# Altium schematic sheet DSP.SchDoc
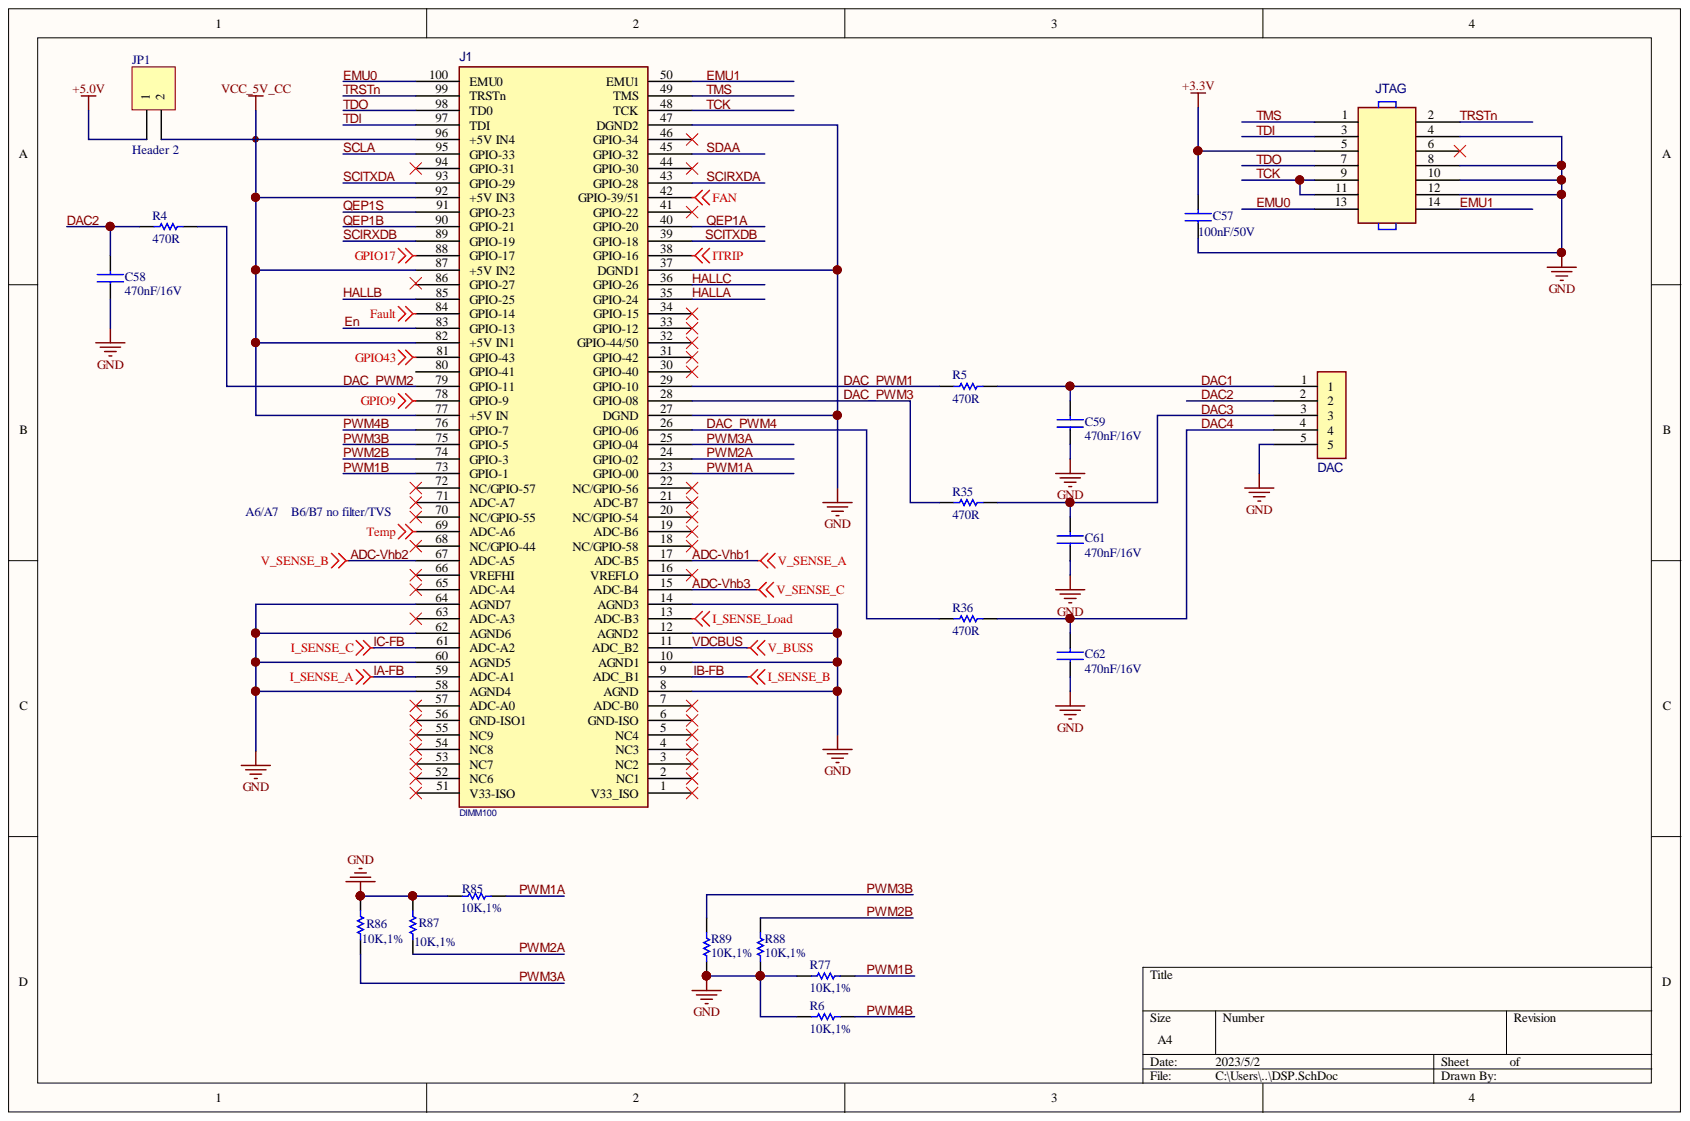
[diagram p0: DSP.SchDoc]

## Parts
C57  100nF/50V  [0603]
C58  470nF/16V  [0603]
C59  470nF/16V  [0603]
C61  470nF/16V  [0603]
C62  470nF/16V  [0603]
DAC  HEADER5x1  [HDR5X1_5.0mm]  (value not drawn)
J1  DIMM100  [DIM100_short]
JP1  Header 2  [HDR1X2]
JTAG  HEADER7x2  [HDR2X7-B]  (value not drawn)
R4  470R  [0603]
R5  470R  [0603]
R6  10K,1%  [0603]
R35  470R  [0603]
R36  470R  [0603]
R77  10K,1%  [0603]
R85  10K,1%  [0603]
R86  10K,1%  [0603]
R87  10K,1%  [0603]
R88  10K,1%  [0603]
R89  10K,1%  [0603]

## Nets
+3.3V: C57.2 JTAG.5
+5.0V: JP1.1
FAN: J1.42(GPIO-39/51)
Fault: J1.84(GPIO-14)
GND: C57.1 C58.1 C59.1 C61.1 C62.1 DAC.5 J1.8(AGND) J1.10(AGND1) J1.12(AGND2) J1.14(AGND3) J1.27(DGND) J1.37(DGND1) J1.47(DGND2) J1.58(AGND4) J1.60(AGND5) J1.62(AGND6) J1.64(AGND7) JTAG.4 JTAG.8 JTAG.10 JTAG.12 R6.1 R77.1 R85.1 R86.1 R87.1 R88.1 R89.1
GPIO9: J1.78(GPIO-9)
GPIO17: J1.88(GPIO-17)
GPIO43: J1.81(GPIO-43)
I_SENSE_Load: J1.13(ADC-B3)
ITRIP: J1.38(GPIO-16)
Temp: J1.69(ADC-A6)
VCC_5V_CC: J1.77(+5V_IN) J1.82(+5V_IN1) J1.87(+5V_IN2) J1.92(+5V_IN3) J1.96(+5V_IN4) JP1.2
ADC-Vhb1: J1.17(ADC-B5)
ADC-Vhb2: J1.67(ADC-A5)
ADC-Vhb3: J1.15(ADC-B4)
DAC1: C59.2 DAC.1 R5.2
DAC2: C58.2 DAC.2 R4.1
DAC3: C61.2 DAC.3 R35.2
DAC4: C62.2 DAC.4 R36.2
DAC_PWM1: J1.29(GPIO-10) R5.1
DAC_PWM2: J1.79(GPIO-11) R4.2
DAC_PWM3: J1.28(GPIO-08) R35.1
DAC_PWM4: J1.26(GPIO-06) R36.1
EMU0: J1.100(EMU0) JTAG.13
EMU1: J1.50(EMU1) JTAG.14
En: J1.83(GPIO-13)
HALLA: J1.35(GPIO-24)
HALLB: J1.85(GPIO-25)
HALLC: J1.36(GPIO-26)
IA-FB: J1.59(ADC-A1)
IB-FB: J1.9(ADC_B1)
IC-FB: J1.61(ADC-A2)
PWM1A: J1.23(GPIO-00) R85.2
PWM1B: J1.73(GPIO-1) R77.2
PWM2A: J1.24(GPIO-02) R87.2
PWM2B: J1.74(GPIO-3) R88.2
PWM3A: J1.25(GPIO-04) R86.2
PWM3B: J1.75(GPIO-5) R89.2
PWM4B: J1.76(GPIO-7) R6.2
QEP1A: J1.40(GPIO-20)
QEP1B: J1.90(GPIO-21)
QEP1S: J1.91(GPIO-23)
SCIRXDA: J1.43(GPIO-28)
SCIRXDB: J1.89(GPIO-19)
SCITXDA: J1.93(GPIO-29)
SCITXDB: J1.39(GPIO-18)
SCLA: J1.95(GPIO-33)
SDAA: J1.45(GPIO-32)
TCK: J1.48(TCK) JTAG.9 JTAG.11
TDI: J1.97(TDI) JTAG.3
TDO: J1.98(TD0) JTAG.7
TMS: J1.49(TMS) JTAG.1
TRSTn: J1.99(TRSTn) JTAG.2
VDCBUS: J1.11(ADC_B2)

Not connected: J1 1(V33_ISO) 2(NC1) 3(NC2) 4(NC3) 5(NC4) 6(GND-ISO) 7(ADC-B0) 16(VREFLO) 18(NC/GPIO-58) 19(ADC-B6) 20(NC/GPIO-54) 21(ADC-B7) 22(NC/GPIO-56) 30(GPIO-40) 31(GPIO-42) 32(GPIO-44/50) 33(GPIO-12) 34(GPIO-15) 41(GPIO-22) 44(GPIO-30) 46(GPIO-34) 51(V33-ISO) 52(NC6) 53(NC7) 54(NC8) 55(NC9) 56(GND-ISO1) 57(ADC-A0) 63(ADC-A3) 65(ADC-A4) 66(VREFHI) 68(NC/GPIO-44) 70(NC/GPIO-55) 71(ADC-A7) 72(NC/GPIO-57) 80(GPIO-41) 86(GPIO-27) 94(GPIO-31); JTAG 6

## Library data of the parts
C57-C59 C61 C62 = Component-CAP: User Parameter 1: foot; Component Kind: Standard; Library Name: AltiumDesigner_Lib-tony.SchLib
DAC = D3_CONN_5: Part Number: D3-0020241; Component Height: 8.00MM; Component Kind: Standard
J1 = DIMM100_controlCARD_28035_28069: Part Number: D3-0020238; Component Height: 31.50MM; Component Kind: Standard
JP1 = Header 2: Header, 2-Pin; Component Kind: Standard; LatestRevisionDate: 17-Jul-2002; LatestRevisionNote: Re-released for DXP Platform.; Library Name: Miscellaneous Connectors.IntLib; Signal Integrity: Connector; Publisher: Altium Limited
JTAG = HEADER 7X2: Part Number: D3-0020001; Component Height: 8.50MM; Component Kind: Standard
R4 R5 R35 R36 = Res1: Resistor; Value: 2.2K; Component Kind: Standard; LatestRevisionDate: 17-Jul-2002; LatestRevisionNote: Re-released for DXP Platform.; Library Name: Miscellaneous Devices.IntLib; PackageReference: AXIAL-0.3; Published: 8-Jun-2000; Signal Integrity: Res; Simulation: RESISTOR; Publisher: Altium Limited
R6 R77 R85-R89 = Res1: Value: 2.2K; Component Kind: Standard; LatestRevisionDate: 17-Jul-2002; LatestRevisionNote: Re-released for DXP Platform.; Library Name: Miscellaneous Devices.IntLib; PackageReference: AXIAL-0.3; Published: 8-Jun-2000; Signal Integrity: Res; Simulation: RESISTOR; Publisher: Altium Limited
